# Altium schematic sheet POWER.SchDoc(POWER)
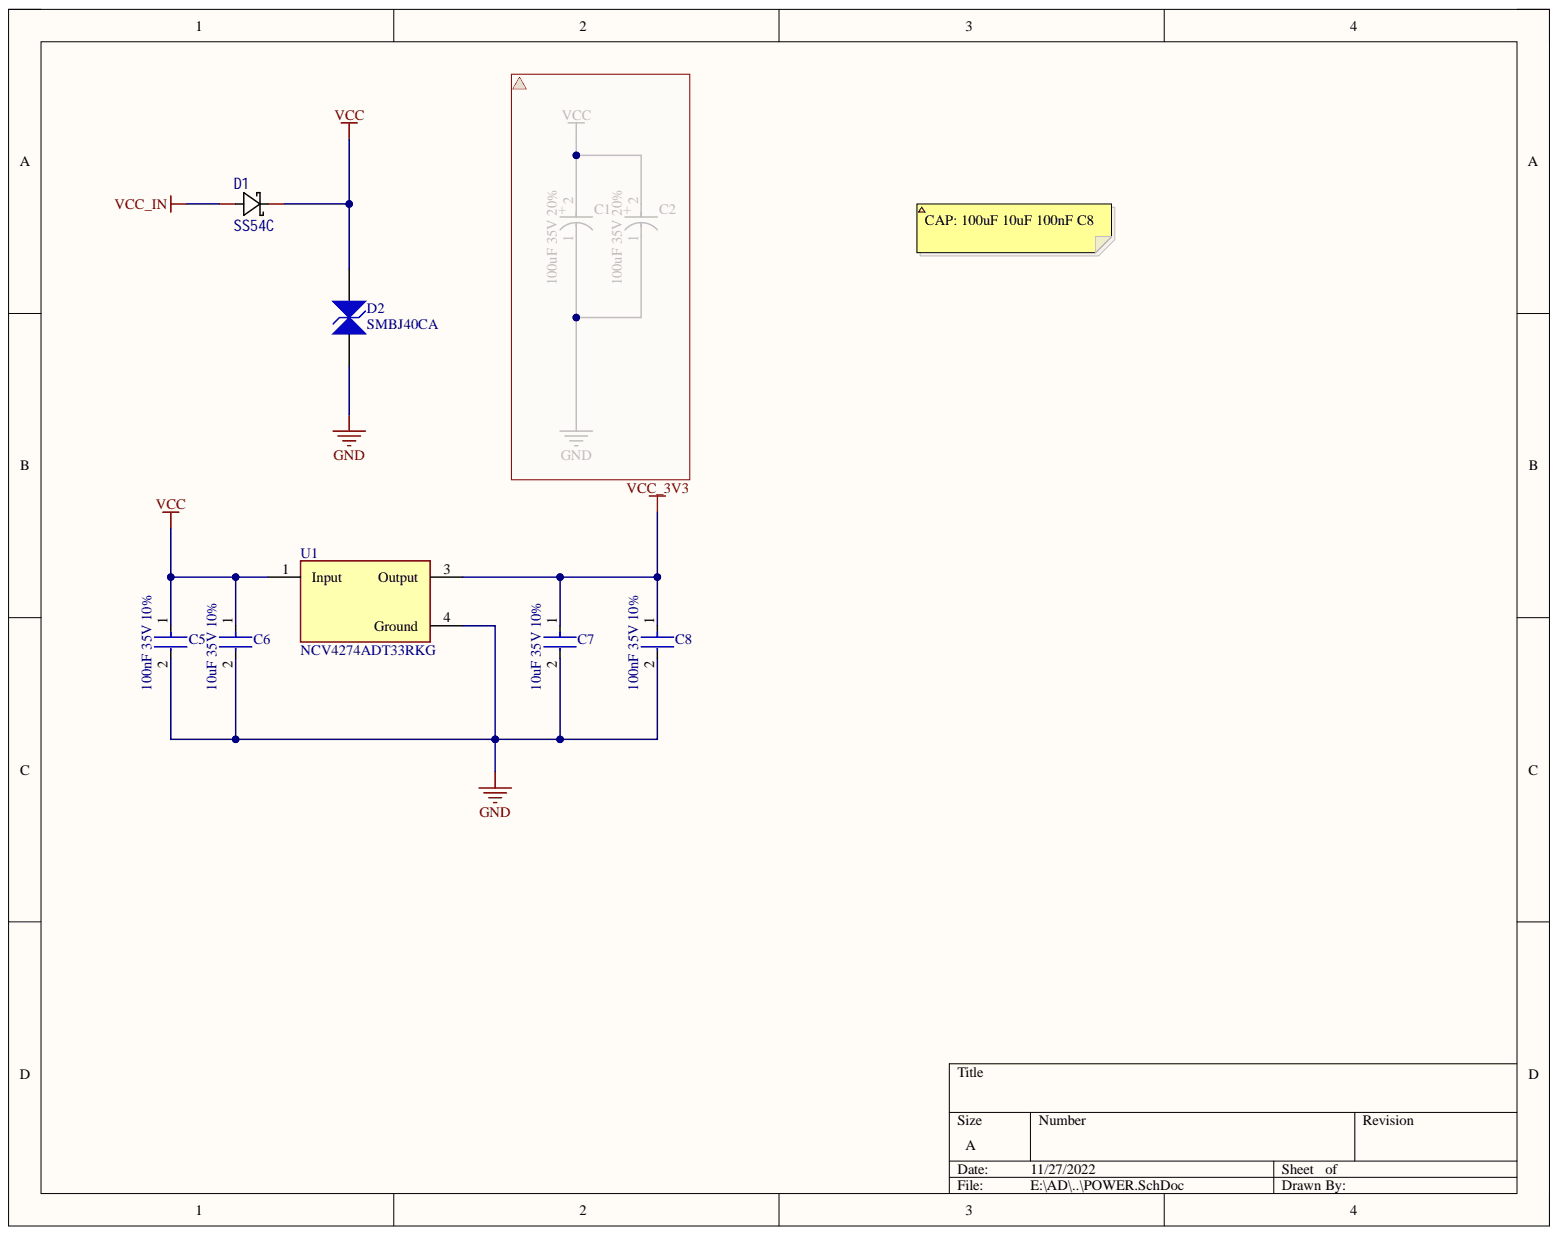
[diagram p0: POWER.SchDoc]

## Parts
C5  100nF 35V 10%  Capacitance: 100nF  Tolerance: 10%  Voltage: 35V  [FP-0402-L_1_0_0_05-W_0_5-IPC_A]
C6  10uF 35V 10%  Capacitance: 10uF  Tolerance: 10%  Voltage Rating: 35V  [FP-1206-L_3_2_0_2-W_1_6_0-MFG]
C7  10uF 35V 10%  Capacitance: 10uF  Tolerance: 10%  Voltage Rating: 35V  [FP-1206-L_3_2_0_2-W_1_6_0-MFG]
C8  100nF 35V 10%  Capacitance: 100nF  Tolerance: 10%  Voltage: 35V  [FP-0402-L_1_0_0_05-W_0_5-IPC_A]
D1  SS54C  [SMC_L6.9-W5.9-LS7.9-RD]
D2  SMBJ40CA  [FAIR-DO-214AA-A-2_V]
U1  NCV4274ADT33RKG  [FP-369C-MFG]

## Nets
GND: C5.2 C6.2 C7.2 C8.2 D2.2 U1.4
VCC: C5.1 C6.1 D1.1 D2.1 U1.1
VCC_3V3: C7.1 C8.1 U1.3
VCC_IN: D1.2

## Library data of the parts
C5 C8 = CMP-2000-06323-3: Dielectric: X5R; Case Code (Imperial): 0402; Case Code (Metric): 1005; Case/Package: 0402; Component Kind: Standard; Construction: Flat; Depth: 0.5mm; Description: 100nF 35V 10%; Halogen Free: Halogen Free; Height: 0.5mm; Length: 1mm; Library Name: Altium Content Vault; Max Operating Temperature: 85°C; Min Operating Temperature: -55°C; Mount: Surface Mount; Number of Pins: 2; Package Quantity: 1; Packaging: Tape and Reel; Radiation Hardening: No; REACH SVHC: No SVHC; Resistor Type: Ceramic; RoHS Compliant: Yes; Series: M; Simulation: GMK105BJ104_V-F; Termination: SMD/SMT; Thickness: 0.022inch; Voltage Rating: 35V; Voltage Rating (DC): 35V; Width: 0.02inch
C6 C7 = CMP-2000-06324-3: None; Dielectric: X7R; Case Code (Imperial): 1206; Case Code (Metric): 3216; Case/Package: 1206; Component Kind: Standard; Height: 1.6mm; Length: 0.126inch; Library Name: Altium Content Vault; Max Operating Temperature: 125°C; Min Operating Temperature: -55°C; Mount: Surface Mount; Package Quantity: 2000; Packaging: Tape and Reel; REACH SVHC: No SVHC; Resistor Type: Ceramic; RoHS Compliant: Yes; Series: M; Simulation: GMK316AB7106_L-TR; Termination: SMD/SMT; Thickness: 0.071inch; Voltage Rating (DC): 35V; Width: 1.6mm
D1 = SS54C_C880739: 二极管配置:-;直流反向耐压(Vr):40V;平均整流电流(Io):5A;正向压降(Vf):550mV@5A;反向电流(Ir):500A@40V;; Manufacturer: Slkor(萨科微); Add into BOM: yes; Average Rectified Current (Io): 5A; Reverse Voltage (Vr): 40V; Component Kind: Standard; Convert to PCB: yes; Datasheet: https://atta.szlcsc.com/upload/public/pdf/source/20201013/C880739_D47B31F19BD092A88A6CE51787FFA2A3.pdf; Device: SS54C_C880739; Diode Configuration: -; Forward Voltage (Vf@If): 550mV@5A; JLCPCB Part Class: 扩展库; LCSC Part Name: 40V 5A 550mV@5A; Library Name: SS54C.IntLib; Manufacturer Part: SS54C; PCB3D: SMC_L6.9-W5.9-LS7.9-RD; Reverse Leakage Current (Ir): 500A@40V; Supplier: LCSC; Supplier Footprint: SMC(DO-214AB); Supplier Part: C880739; Symbol: SS54C_C880739
D2 = CMP-2000-07097-1: Transient Voltage Suppressor, 600 W, 40 V, -55 to 150 degC, 2-Pin SMD, RoHS, Tape and Reel; Manufacturer: Fairchild Semiconductor; Breakdown Voltage-Max: 49.1V; Breakdown Voltage-Min: 44.4V; Clamping Voltage-Max: 64.5V; Directional: Bi-Directional; Power Dissipation: 600W; Component Kind: Standard; Datasheet URL: https://www.fairchildsemi.com/datasheets/SM/SMBJ40CA.pdf; Datasheet Version: Rev. 1.1.1, 11/2014; Library Name: Altium Content Vault; Manufacturer URL: https://www.fairchildsemi.com/; Mounting Technology: SurfaceMount; Package Description: 2-Pin Surface Mount Device, Body 4.4 x 3.625 mm; Package Reference: DO-214AA
U1 = CMP-07128-000011-1: IC REG LINEAR 3.3V 400MA DPAK; Manufacturer: ON SEMICONDUCTOR; Accuracy Percentage: 2%; Automotive Grade: Grade 0; Dropout Voltage: 1.27V; Max Junction Temp: +150°C; Max Supply Voltage: +45V; Min Supply Voltage: -42V; Nominal Supply Current: 145-30000uA; Number Of Outputs: 1; Output Type: Fixed; Standoff Height: 0mm; Automotive: Yes; Category: IC; Component Kind: Standard; ComponentLink2Description: Datasheet; ComponentLink2URL: https://www.onsemi.com/pub/Collateral/NCV4274-D.PDF; Device Class L1: Integrated Circuits (ICs); Device Class L2: Power Management ICs; Device Class L3: Voltage Regulators - Linear; Digikey Description: IC REG LINEAR 3.3V 400MA DPAK; Footprint Url: https://www.onsemi.com/pub/Collateral/369C.PDF; Height: 2.51mm; Lead Free: Yes; Library Name: Altium Content Vault; Manufacturer Part Number: NCV4274ADT33RKG; Mouser Description: IC REG LINEAR 3.3V 400MA DPAK-3; Octopart: https://octopart.com/ncv4274adt33rkg-on+semiconductor-10550992; Output Current: 400mA; Output Voltage: 3.3V; Package: 369C; RoHS: TRUE; Temperature: -40°C to +150°C; Temperature Range High: +150°C; Temperature Range Low: -40°C
